# Altium schematic sheet mShield.SchDoc
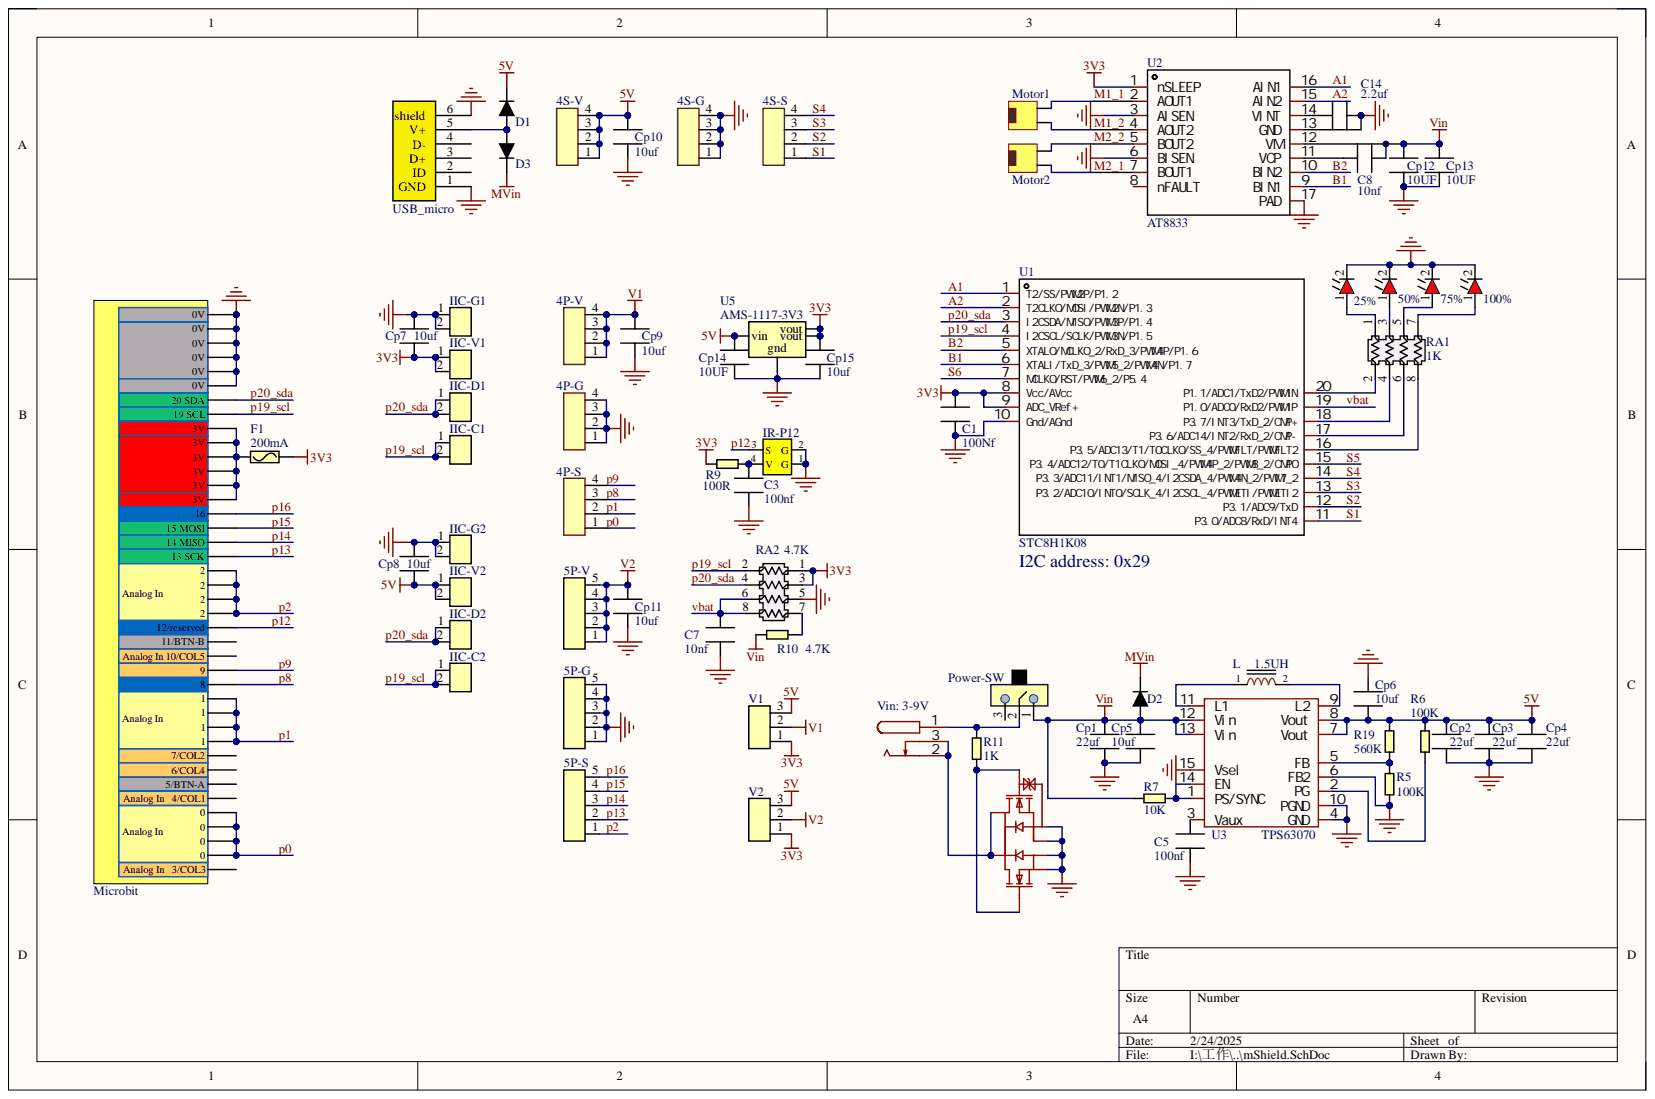
[diagram p0: mShield.SchDoc]

## Parts
4P-G  Connector-4P  [1X4-2.54MM]  (value not drawn)
4P-S  Connector-4P  [1X4-2.54MM]  (value not drawn)
4P-V  Connector-4P  [1X4-2.54MM]  (value not drawn)
4S-G  Connector-4P  [1X4-2.54MM]  (value not drawn)
4S-S  Connector-4P  [1X4-2.54MM]  (value not drawn)
4S-V  Connector-4P  [1X4-2.54MM]  (value not drawn)
5P-G  Connector-5P  [1X05-2.54mm]  (value not drawn)
5P-S  Connector-5P  [1X05-2.54mm]  (value not drawn)
5P-V  Connector-5P  [1X05-2.54mm]  (value not drawn)
25%  LED  [LED-0603]  (value not drawn)
50%  LED  [LED-0603]  (value not drawn)
75%  LED  [LED-0603]  (value not drawn)
100%  LED  [LED-0603]  (value not drawn)
C1  100Nf  [RES-0603]
C3  100nf  [RES-0603]
C5  100nf  [RES-0603]
C7  10nf  [RES-0603]
C8  10nf  [RES-0603]
C14  2.2uf  [RES-0603]
Cp1  22uf  [0805]
Cp2  22uf  [0805]
Cp3  22uf  [0805]
Cp4  22uf  [0805]
Cp5  10uf  [0805]
Cp6  10uf  [0805]
Cp7  10uf  [0805]
Cp8  10uf  [0805]
Cp9  10uf  [0805]
Cp10  10uf  [0805]
Cp11  10uf  [0805]
Cp12  10UF  [0805]
Cp13  10UF  [0805]
Cp14  10UF  [0805]
Cp15  10uf  [0805]
D1  Diode  [D34-1]  (value not drawn)
D2  Diode  [D34-1]  (value not drawn)
D3  Diode  [D34-1]  (value not drawn)
F1  200mA  [0603]
IIC-C1  Connector_2P  [1*2-2.54MM]  (value not drawn)
IIC-C2  Connector_2P  [1*2-2.54MM]  (value not drawn)
IIC-D1  Connector_2P  [1*2-2.54MM]  (value not drawn)
IIC-D2  Connector_2P  [1*2-2.54MM]  (value not drawn)
IIC-G1  Connector_2P  [1*2-2.54MM]  (value not drawn)
IIC-G2  Connector_2P  [1*2-2.54MM]  (value not drawn)
IIC-V1  Connector_2P  [1*2-2.54MM]  (value not drawn)
IIC-V2  Connector_2P  [1*2-2.54MM]  (value not drawn)
IR-P12  IRM-H638T/TR2  [IR_R]  (value not drawn)
L  1.5UH  [电感-7.5*6.6*4mm]
Microbit  Microbit-40p  [micro:bit slot]  (value not drawn)
Motor1  CONNECTOR-2.54MM-2P  [connector-2P-2.54-SMD - 卧式]  (value not drawn)
Motor2  CONNECTOR-2.54MM-2P  [connector-2P-2.54-SMD - 卧式]  (value not drawn)
Power-SW  SS-12F21G3  [SS-12F23VGx]  (value not drawn)
R5  100K  [0603]
R6  100K  [0603]
R7  10K  [0603]
R9  100R  [0603]
R10  4.7K  [0603]
R11  1K  [0603]
R19  560K  [0603]
RA1  1K  [排阻-4]
RA2  4.7K  [排阻-4]
U1  STC8H1K08  [TSSOP-20]
U2  AT8833  [HTSSOP-16_L5.0-W4.4-P0.65-LS6.4-BL-EP]
U3  TPS63070  [VQFN-HR-15_L3.0-W2.5-P0.50-BL_TPS63070RNMR]
U4  AO8814-HXY  [TSSOP-8_L4.4-W3.0-P0.65-LS6.4-BL]  (value not drawn)
U5  AMS-1117-3V3  [SOT-223]
USB_micro  USB_micro  [micro_USB]
V1  Connector-3P  [1x3-2.54mm - duplicate]  (value not drawn)
V2  Connector-3P  [1x3-2.54mm - duplicate]  (value not drawn)
Vin:_3-9V  DC-2.1/2.5  [DC-044]  (value not drawn)

## Nets
3V3: C1.2 Cp7.2 Cp15.2 F1.2 IIC-V1.1 IIC-V1.2 R9.1 RA2.1 RA2.3 U1.8(Vcc/AVcc) U1.9(ADC_VRef+) U2.1(nSLEEP) U5.2(vout) U5.4(vout) V1.1 V2.1
5V: 4S-V.1 4S-V.2 4S-V.3 4S-V.4 Cp2.2 Cp3.2 Cp4.1 Cp6.1 Cp8.1 Cp10.2 Cp14.2 D1.2 IIC-V2.1 IIC-V2.2 R6.2 R19.2 U3.7(Vout) U3.8(Vout) U5.3(vin) V1.3 V2.3
GND: 4P-G.1 4P-G.2 4P-G.3 4P-G.4 4S-G.1 4S-G.2 4S-G.3 4S-G.4 5P-G.1 5P-G.2 5P-G.3 5P-G.4 5P-G.5 25%.2 50%.2 75%.2 100%.2 C1.1 C3.2 C5.1 C7.2 C14.1 Cp1.1 Cp2.1 Cp3.1 Cp4.2 Cp5.1 Cp6.2 Cp7.1 Cp8.2 Cp9.1 Cp10.1 Cp11.1 Cp12.1 Cp13.1 Cp14.1 Cp15.1 IIC-G1.1 IIC-G1.2 IIC-G2.1 IIC-G2.2 IR-P12.1(G) IR-P12.2(G) Microbit.1(0V) Microbit.2(0V) Microbit.3(0V) Microbit.4(0V) Microbit.5(0V) Microbit.6(0V) R5.2 RA2.5 U1.10(Gnd/AGnd) U2.3(AISEN) U2.6(BISEN) U2.13(GND) U2.17(PAD) U3.4(GND) U3.6(FB2) U3.10(PGND) U3.15(Vsel) U4.2 U4.3 U4.6 U4.7 U5.1 USB_micro.1(GND) USB_micro.6(shield)
MVin: D2.2 D3.2
V1: 4P-V.1 4P-V.2 4P-V.3 4P-V.4 Cp9.2 V1.2
V2: 5P-V.1 5P-V.2 5P-V.3 5P-V.4 5P-V.5 Cp11.2 V2.2
Vin: C8.1 Cp1.2 Cp5.2 Cp12.2 Cp13.2 D2.1 Power-SW.1 R7.2 R10.1 U2.12(VM) U3.12(Vin) U3.13(Vin)
A1: U1.1(T2/SS/PWM2P/P1.2) U2.16(AIN1)
A2: U1.2(T2CLKO/MOSI/PWM2N/P1.3) U2.15(AIN2)
B1: U1.6(XTALI/TxD_3/PWM5_2/PWM4N/P1.7) U2.9(BIN1)
B2: U1.5(XTALO/MCLKO_2/RxD_3/PWM4P/P1.6) U2.10(BIN2)
M1_1: Motor1.1 U2.2(AOUT1)
M1_2: Motor1.2 U2.4(AOUT2)
M2_1: Motor2.2 U2.7(BOUT1)
M2_2: Motor2.1 U2.5(BOUT2)
p0: 4P-S.1 Microbit.36 Microbit.37 Microbit.38 Microbit.39
p1: 4P-S.2 Microbit.28 Microbit.29 Microbit.30 Microbit.31
p2: 5P-S.1 Microbit.19 Microbit.20 Microbit.21 Microbit.22
p8: 4P-S.3 Microbit.27
p9: 4P-S.4 Microbit.26
p12: IR-P12.3(S) Microbit.23(12/reserved)
p13: 5P-S.2 Microbit.18(13/SCK)
p14: 5P-S.3 Microbit.17(14/MISO)
p15: 5P-S.4 Microbit.16(15/MOSI)
p16: 5P-S.5 Microbit.15
p19_scl: IIC-C1.1 IIC-C1.2 IIC-C2.1 IIC-C2.2 Microbit.8(19/SCL) RA2.2 U1.4(I2CSCL/SCLK/PWM3N/P1.5)
p20_sda: IIC-D1.1 IIC-D1.2 IIC-D2.1 IIC-D2.2 Microbit.7(20/SDA) RA2.4 U1.3(I2CSDA/MISO/PWM3P/P1.4)
S1: 4S-S.1 U1.11(P3.0/ADC8/RxD/INT4)
S2: 4S-S.2 U1.12(P3.1/ADC9/TxD)
S3: 4S-S.3 U1.13(P3.2/ADC10/INT0/SCLK_4/I2CSCL_4/PWMETI/PWMETI2)
S4: 4S-S.4 U1.14(P3.3/ADC11/INT1/MISO_4/I2CSDA_4/PWM4N_2/PWM7_2)
S5: U1.15(P3.4/ADC12/T0/T1CLKO/MOSI_4/PWM4P_2/PWM8_2/CMPO)
S6: U1.7(MCLKO/RST/PWM6_2/P5.4)
vbat: C7.1 RA2.6 RA2.8 U1.19(P1.0/ADC0/RxD2/PWM1P)
Net25%_1: 25%.1 RA1.1
Net50%_1: 50%.1 RA1.3
Net75%_1: 75%.1 RA1.5
Net100%_1: 100%.1 RA1.7
NetC3_1: C3.1 IR-P12.4(V) R9.2
NetC5_2: C5.2 U3.3(Vaux)
NetC8_2: C8.2 U2.11(VCP)
NetC14_2: C14.2 U2.14(VINT)
NetD1_1: D1.1 D3.1 USB_micro.5(V+)
NetF1_1: F1.1 Microbit.9(3V) Microbit.10(3V) Microbit.11(3V) Microbit.12(3V) Microbit.13(3V) Microbit.14(3V)
NetL_1: L.1 U3.11(L1)
NetL_2: L.2 U3.9(L2)
NetPower-SW_2: Power-SW.2 R11.1 Vin:_3-9V.1
NetR5_1: R5.1 R19.1 U3.5(FB)
NetR6_1: R6.1 U3.2(PG)
NetR7_1: R7.1 U3.1(PS/SYNC) U3.14(EN)
NetR10_2: R10.2 RA2.7
NetR11_2: R11.2 U4.4 U4.5
NetRA1_2: RA1.2 U1.20(P1.1/ADC1/TxD2/PWM1N)
NetRA1_4: RA1.4 U1.18(P3.7/INT3/TxD_2/CMP+)
NetRA1_6: RA1.6 U1.17(P3.6/ADC14/INT2/RxD_2/CMP-)
NetRA1_8: RA1.8 U1.16(P3.5/ADC13/T1/T0CLKO/SS_4/PWMFLT/PWMFLT2)
NetU4_1: U4.1 U4.8 Vin:_3-9V.2 Vin:_3-9V.3

Not connected: Microbit 24(11/BTN-B) 25(Analog_In_10/COL5) 32(7/COL2) 33(6/COL4) 34(5/BTN-A) 35(4/COL1) 40(3/COL3); Power-SW 3; U2 8(nFAULT); USB_micro 2(ID) 3(D+) 4(D-)

## Library data of the parts
C1 C3 C5 C7 C8 C14 = CAP-0603: Component Kind: Standard; Library Name: Altium_Zizhi_Lib.SchLib
Cp1-Cp11 Cp15 = CAP-0805: Component Kind: Standard; Library Name: Altium_Zizhi_Lib.SchLib
Cp12-Cp14 = CAP-1210: Component Kind: Standard; Library Name: Altium_Zizhi_Lib.SchLib
F1 = FUSE: Component Kind: Standard; Library Name: Altium_Zizhi_Lib.SchLib
L = 电感33UH: Component Kind: Standard; Library Name: Altium_Zizhi_Lib.SchLib
Power-SW = switch_3P: Component Kind: Standard; Library Name: Altium_Zizhi_Lib.SchLib
R5-R7 R9-R11 R19 = Resistor: Component Kind: Standard; Library Name: Altium_Zizhi_Lib.SchLib
RA1 RA2 = Resistor array: Component Kind: Standard; Library Name: Altium_Zizhi_Lib.SchLib
U4 = AO8814-HXY: Manufacturer: HXY MOSFET(华轩阳电子); Add into BOM: yes; Continuous Drain Current (Id): 7.5A; Drain Source On Resistance (RDS(on)@Vgs,Id): 13mΩ@4.5V,3.5A; Drain Source Voltage (Vdss): 20V; Power Dissipation (Pd): 1.25W; Comment_1: AO8814-HXY; Component Kind: Standard; Convert to PCB: yes; Datasheet: https://atta.szlcsc.com/upload/public/pdf/source/20231222/43B68DCF489C531DF56929DC8616AD30.pdf; Device: AO8814-HXY; JLCPCB Part Class: Extended Part; LCSC Part Name: N沟道 耐压:20V 电流:7.5A; Manufacturer Part: AO8814-HXY; Supplier: LCSC; Supplier Footprint: TSSOP-8; Supplier Part: C19723843; Symbol: AO8814-HXY; Type: N沟道
U5 = AMS-1117: Component Kind: Standard; Library Name: Altium_Zizhi_Lib.SchLib
4P-G 4P-S 4P-V 4S-G 4S-S 4S-V = Connector-4P: Component Kind: Standard; Library Name: Altium_Zizhi_Lib.SchLib
5P-G 5P-S 5P-V = Connector-5P: Component Kind: Standard; Library Name: Altium_Zizhi_Lib.SchLib
25% 50% 75% 100% = LED: Component Kind: Standard; Library Name: Altium_Zizhi_Lib.SchLib
D1-D3 = Diode: Component Kind: Standard; Library Name: Altium_Zizhi_Lib.SchLib
IIC-C1 IIC-C2 IIC-D1 IIC-D2 IIC-G1 IIC-G2 IIC-V1 IIC-V2 = Connector_2P: Component Kind: Standard; Library Name: Altium_Zizhi_Lib.SchLib
IR-P12 = IRM-H638T/TR2: Component Kind: Standard; Library Name: Altium_Zizhi_Lib.SchLib
Microbit = Microbit-40p: Component Kind: Standard; Library Name: Altium_Zizhi_Lib.SchLib
Motor1 Motor2 = CONNECTOR-2.54MM-2P: Component Kind: Standard; Library Name: Altium_Zizhi_Lib.SchLib
U1 = STC8H1K08: Component Kind: Standard; Library Name: Altium_Zizhi_Lib.SchLib
U2 = AT8833: Component Kind: Standard; Library Name: Altium_Zizhi_Lib.SchLib
U3 = TPS63070: Component Kind: Standard; Library Name: Altium_Zizhi_Lib.SchLib
USB_micro = USB_micro: Component Kind: Standard; Library Name: Altium_Zizhi_Lib.SchLib
V1 V2 = Connector-3P: Component Kind: Standard; Library Name: Altium_Zizhi_Lib.SchLib
Vin:_3-9V = DC-2.1/2.5: Component Kind: Standard; Library Name: Altium_Zizhi_Lib.SchLib
